# Altium schematic sheet ButtonInputs.SchDoc
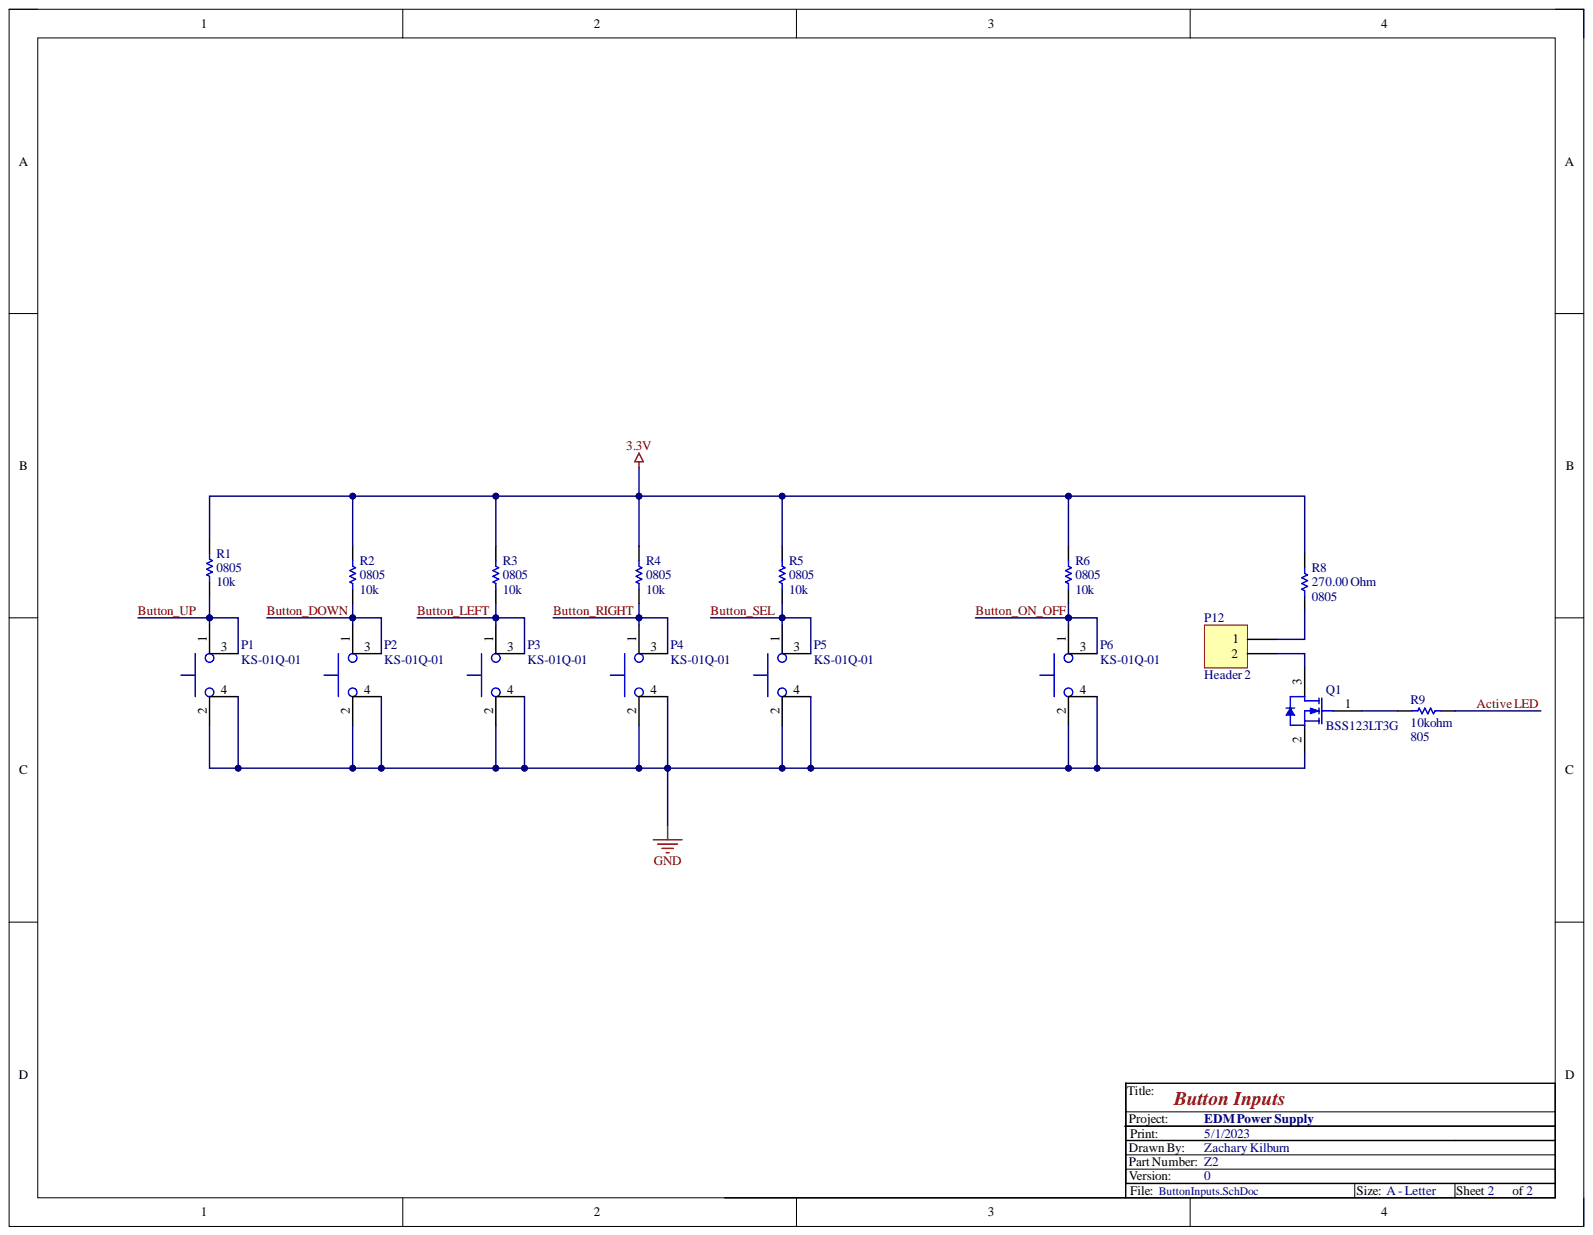
[diagram p0: ButtonInputs.SchDoc]

## Parts
P1  Part Number: KS-01Q-01  [E-Switch_29-KS01Q01_0]  (hidden comment: Switch_E-Switch_KS-01Q-01_eec)
P2  Part Number: KS-01Q-01  [E-Switch_29-KS01Q01_0]  (hidden comment: Switch_E-Switch_KS-01Q-01_eec)
P3  Part Number: KS-01Q-01  [E-Switch_29-KS01Q01_0]  (hidden comment: Switch_E-Switch_KS-01Q-01_eec)
P4  Part Number: KS-01Q-01  [E-Switch_29-KS01Q01_0]  (hidden comment: Switch_E-Switch_KS-01Q-01_eec)
P5  Part Number: KS-01Q-01  [E-Switch_29-KS01Q01_0]  (hidden comment: Switch_E-Switch_KS-01Q-01_eec)
P6  Part Number: KS-01Q-01  [E-Switch_29-KS01Q01_0]  (hidden comment: Switch_E-Switch_KS-01Q-01_eec)
P12  Header 2  [HDR1X2]
Q1  BSS123LT3G  [ONSC-SOT-23-3-318-08_V]
R1  Package Reference: 0805  Resistance: 10k  [RESC2013X60X35ML10T20]  (hidden comment: RC0805JR-0710KL)
R2  Package Reference: 0805  Resistance: 10k  [RESC2013X60X35ML10T20]  (hidden comment: RC0805JR-0710KL)
R3  Package Reference: 0805  Resistance: 10k  [RESC2013X60X35ML10T20]  (hidden comment: RC0805JR-0710KL)
R4  Package Reference: 0805  Resistance: 10k  [RESC2013X60X35ML10T20]  (hidden comment: RC0805JR-0710KL)
R5  Package Reference: 0805  Resistance: 10k  [RESC2013X60X35ML10T20]  (hidden comment: RC0805JR-0710KL)
R6  Package Reference: 0805  Resistance: 10k  [RESC2013X60X35ML10T20]  (hidden comment: RC0805JR-0710KL)
R8  value 270.00 Ohm  Case-EIA: 0805  [RESC0805(2012)_N]  (hidden comment: 270R 5% 0805(2012))
R9  Resistance: 10kohm  [RESC2013X60X35ML10T20]  (hidden comment: RC0805JR-0710KL)

## Nets
3.3V: R1.2 R2.2 R3.2 R4.2 R5.2 R6.2 R8.2
GND: P1.2 P1.4 P2.2 P2.4 P3.2 P3.4 P4.2 P4.4 P5.2 P5.4 P6.2 P6.4 Q1.2
Active LED: R9.1
Button_DOWN: P2.1 P2.3 R2.1
Button_LEFT: P3.1 P3.3 R3.1
Button_ON_OFF: P6.1 P6.3 R6.1
Button_RIGHT: P4.1 P4.3 R4.1
Button_SEL: P5.1 P5.3 R5.1
Button_UP: P1.1 P1.3 R1.1
NetP12_1: P12.1 R8.1
NetP12_2: P12.2 Q1.3
NetQ1_1: Q1.1 R9.2

## Library data of the parts
P1-P6 = Switch_E-Switch_KS-01Q-01_eec: SWITCH Pushbutton Switch SPST-NO Standard Through Hole; Actuator Type: Round, Button; Capacitance: 1.0pF; Category 8Ce341E9Bf4C5724: Switches; Category Db391633E8F300Fe: Industrial Control; Current Rating: 10mA (DC); Electrical Life: 250,000 Cycles; Mechanical Life: 500,000 Cycles; Mfg Package Ident: 29-KS01Q01; Num Pins: 4; Value: 1.0pF; Voltage Rating - DC: 35V; Voltage Rating AC: 35 V; Category 2Bfae6F01857F03D: Pushbutton; Component Kind: Standard; ComponentLink1Description: Upverter; ComponentLink1URL: https://upverter.com/upn/revision/1624ef17402244a7/; ComponentLink2Description: Datasheet; ComponentLink2URL: https://upverter.com/datasheet/3b708556f29b55c7f5db677c77a74973be95ebea.pdf; Digikey Description: SWITCH Pushbutton Switch SPST-NO Standard Through Hole; Digikey Part Number: EG4791-ND; helpURL: https://upverter.com/upn/revision/1624ef17402244a7/; Lead Free: yes; Manufacturer: E-Switch; Mouser Part Number: 612-KS-01Q-01; Octopart Part Number: 72a6bab923faad61; Package: 29-KS01Q01; Price: 0.435405037545; RoHS: TRUE; Supplier 1: Digi-Key; Supplier 2: Mouser; Supplier Part Number 1: EG4791-ND; Supplier Part Number 2: 612-KS-01Q-01; Temperature: -20°C to +85°C; Temperature Range High: +85°C; Temperature Range Low: -20°C; Library Name: EDM.IntLib
P12 = Header 2: Header, 2-Pin; Component Kind: Standard; LatestRevisionDate: 17-Jul-2002; LatestRevisionNote: Re-released for DXP Platform.; Library Name: Miscellaneous Connectors.IntLib; Signal Integrity: Connector; Publisher: Altium Limited
Q1 = CMP-1058-00787-1: Power MOSFET, 170 mA, 100 V, N-Channel, 3-Pin SOT-23, Pb-Free, Tape and Reel; Manufacturer: On Semiconductor; Channel Polarity: N Channel; Ciss Typ (pF): 20; Configuration: Single; Coss Typ (pF): 9; Crss Typ (pF): 4; ID Max (A): 0.17; PD Max (W): 0.225; V(BR)DSS Min (V): 100; VGS(th) Max (V): 2.6; Component Kind: Standard; ComponentLink1Description: Manufacturer URL; ComponentLink1URL: http://www.onsemi.com/; ComponentLink2Description: Datasheet; ComponentLink2URL: http://www.onsemi.com/pub_link/Collateral/BSS123LT1-D.PDF; ComponentLink3Description: Package Specification; ComponentLink3URL: http://www.onsemi.cn/pub_link/Collateral/318-08.PDF; DatasheetVersion: Rev. 8; Library Name: Altium Content Vault; Mounting Technology: Surface Mount; Package Type: SOT-23-3; PackageDescription: 3-Pin Small Outline Transistor, Body 2.92 x 1.3 mm, Pitch 0.95 mm; PackageReference: SOT-23-3-318-08; PackageVersion: Rev. AP, 11/2009; Packing: Tape and Reel; PartNumber: BSS123LT3G; RoHS: Pb-Free; VGS Max (V): 20
R1-R6 R9 = CMP-2000-05025-1: Chip Resistor, 10 KOhm, +/- 5%, 0.125 W, -55 to 155 degC, 0805 (2012 Metric), RoHS, Tape and Reel; Manufacturer: Yageo; Power Rating: 250mW; Product Range: RCSeries; Resistor Case Style: 0805[2012Metric]; Resistor Element Type: ThickFilm; SVHC: ToBeAdvised; Temperature Coefficient: ±100ppm/degC; Voltage Rating: 150V; Automotive Qualification Standard: -; Component Kind: Standard; Datasheet URL: http://www.yageo.ru/pdf/RC0805.pdf; Datasheet Version: REV. 2, 09/2004; Library Name: Altium Content Vault; Manufacturer URL: http://www.yageo.com/; MSL: MSL1-Unlimited; Package Description: 2-Pin Surface Mounted Device, Body 2 x 1.25 mm; Packaging: CutTape; Resistance Tolerance: ±5%
  R9: Package Reference: 805
R8 = CMP-1013-00060-1: 270R 0.125W 5% 0805 (2012 Metric)  SMD; Case-Metric: 2012; Component Kind: Standard; Library Name: Altium Content Vault; Power: 0.125 W; Technology: SMT; Tolerance: 5 %
